# Altium schematic sheet Drive.SCHDOC(Drive)
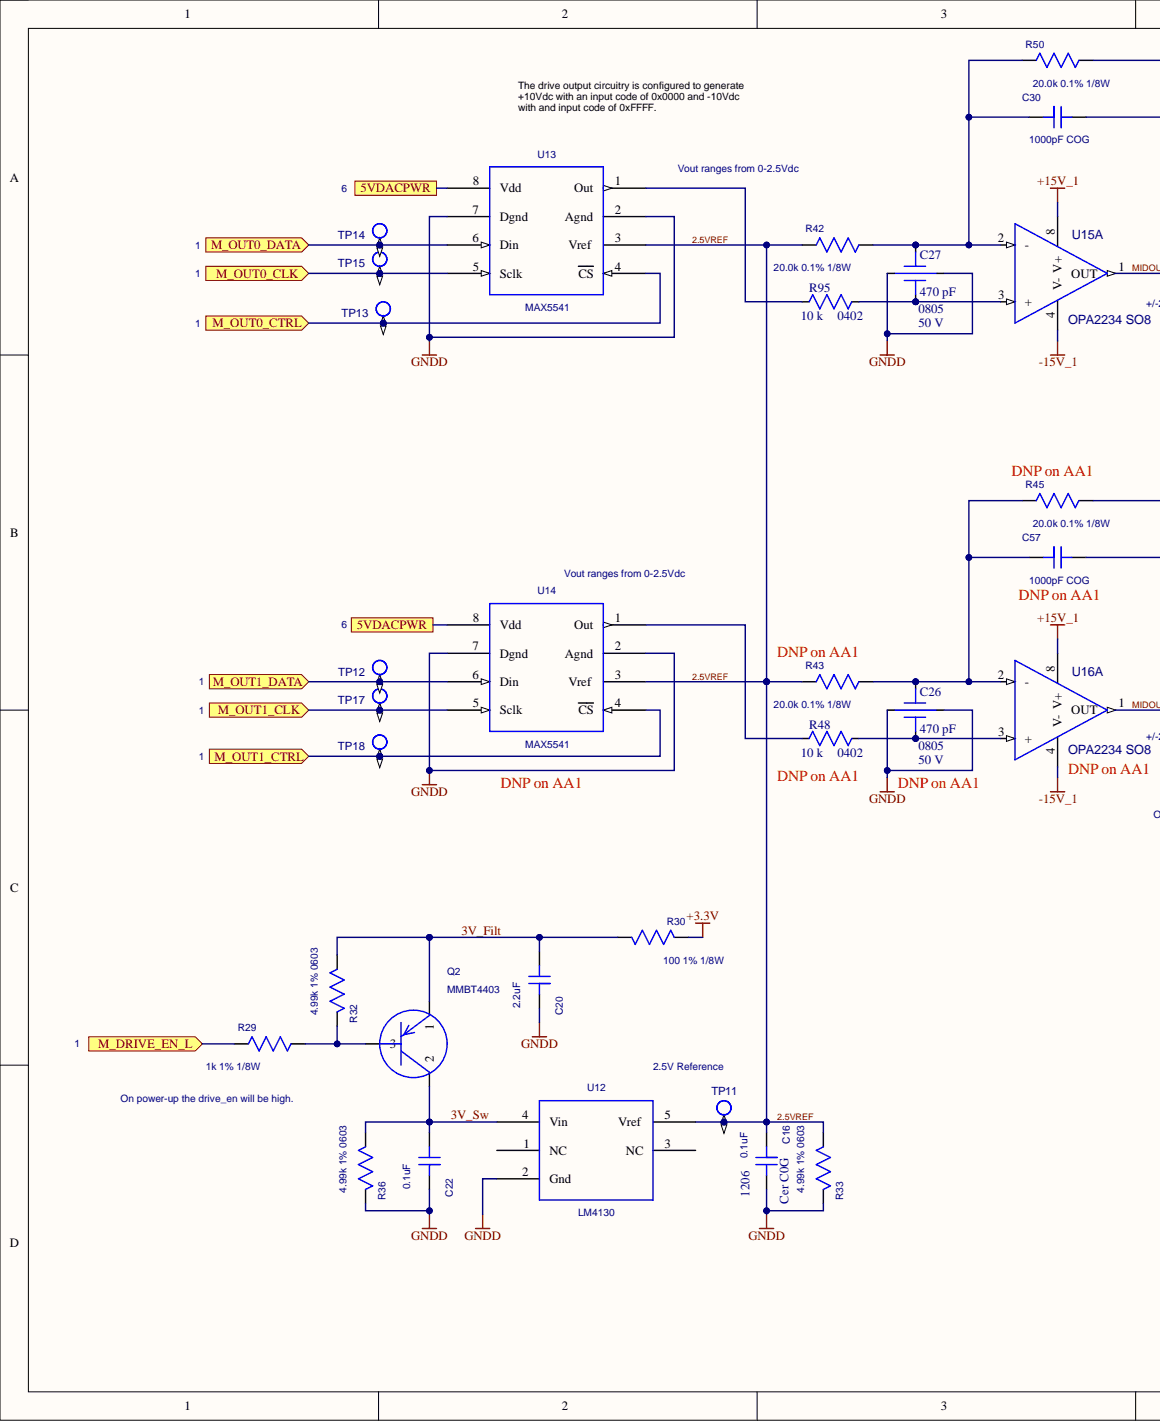
[diagram p0: Drive.SCHDOC, left]
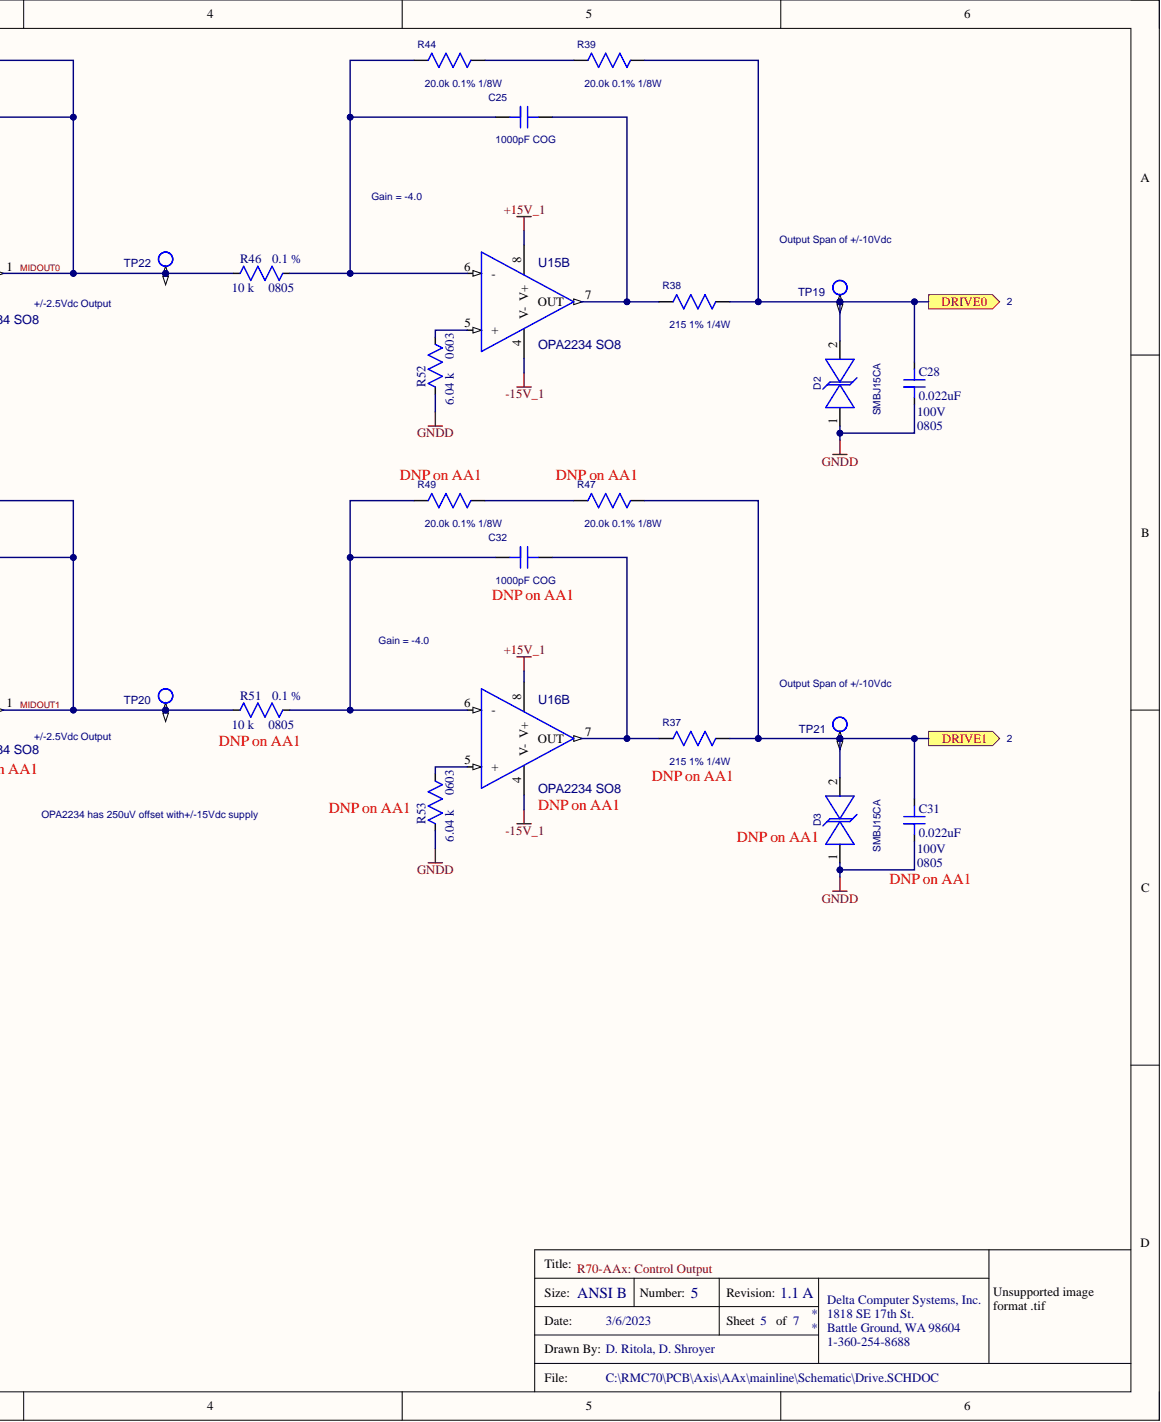
[diagram p1: Drive.SCHDOC, right]

## Parts
C16  0.1uF  Case: 1206  Type: Cer C0G  [CC1206]
C20  2.2uF  [CC0603]
C22  0.1uF  [CC0603]
C25  1000pF COG  [CC0805]
C26  470 pF  CaseSize: 0805  RatedVoltage: 50 V  [CAP X2Y [0805]]
C27  470 pF  CaseSize: 0805  RatedVoltage: 50 V  [CAP X2Y [0805]]
C28  0.022uF  CaseSize: 0805  RatedVoltage: 100V  [CAPC2012-N [0805]]
C30  1000pF COG  [CC0805]
C31  0.022uF  CaseSize: 0805  RatedVoltage: 100V  [CAPC2012-N [0805]]
C32  1000pF COG  [CC0805]
C57  1000pF COG  [CC0805]
D2  SMBJ15CA  [TRANZORBSMT]
D3  SMBJ15CA  [TRANZORBSMT]
Q2  MMBT4403  [SOT346]
R29  1k 1% 1/8W  [CC0805]
R30  100 1% 1/8W  [CC0805]
R32  4.99k 1% 0603  [CC0603]
R33  4.99k 1% 0603  [CC0603]
R36  4.99k 1% 0603  [CC0603]
R37  215 1% 1/4W  [1206]
R38  215 1% 1/4W  [1206]
R39  20.0k 0.1% 1/8W  [CC0805]
R42  20.0k 0.1% 1/8W  [CC0805]
R43  20.0k 0.1% 1/8W  [CC0805]
R44  20.0k 0.1% 1/8W  [CC0805]
R45  20.0k 0.1% 1/8W  [CC0805]
R46  10 k  CaseSize: 0805  Tolerance: 0.1 %  [RESC2012-N [0805]]
R47  20.0k 0.1% 1/8W  [CC0805]
R48  10 k  CaseSize: 0402  [RESC1005-N [0402]]
R49  20.0k 0.1% 1/8W  [CC0805]
R50  20.0k 0.1% 1/8W  [CC0805]
R51  10 k  CaseSize: 0805  Tolerance: 0.1 %  [RESC2012-N [0805]]
R52  6.04 k  CaseSize: 0603  [RESC1608-N [0603]]
R53  6.04 k  CaseSize: 0603  [RESC1608-N [0603]]
R95  10 k  CaseSize: 0402  [RESC1005-N [0402]]
TP11  TP1  [TESTP_XY60D40]  (no BOM)
TP12  TP1  [TESTP_XY60D40]  (no BOM)
TP13  TP1  [TESTP_XY60D40]  (no BOM)
TP14  TP1  [TESTP_XY60D40]  (no BOM)
TP15  TP1  [TESTP_XY60D40]  (no BOM)
TP17  TP1  [TESTP_XY60D40]  (no BOM)
TP18  TP1  [TESTP_XY60D40]  (no BOM)
TP19  TP1  [TESTP_XY60D40]  (no BOM)
TP20  TP1  [TESTP_XY60D40]  (value not drawn)  (no BOM)
TP21  TP1  [TESTP_XY60D40]  (value not drawn)  (no BOM)
TP22  TP1  [TESTP_XY60D40]  (value not drawn)  (no BOM)
U12  LM4130  [SOT23-5]
U13  MAX5541  [SO8]
U14  MAX5541  [SO8]
U15  OPA2234 SO8  [SO8]  (parts A,B)
U16  OPA2234 SO8  [SO8]  (parts A,B)

## Ports
5VDACPWR, DRIVE0, DRIVE1, M_DRIVE_EN_L, M_OUT0_CLK, M_OUT0_CTRL, M_OUT0_DATA, M_OUT1_CLK, M_OUT1_CTRL, M_OUT1_DATA

## Nets
+3.3V: R30.1
+15V_1: U15.8(V+) U16.8(V+)
-15V_1: U15.4(V-) U16.4(V-)
GNDD: C16.1 C20.2 C22.2 C26.3 C26.4 C27.3 C27.4 C28.2 C31.2 D2.1 D3.1 R33.1 R36.1 R52.1 R53.1 U12.2(Gnd) U13.2(Agnd) U13.7(Dgnd) U14.2(Agnd) U14.7(Dgnd)
2.5VREF: C16.2 R33.2 R42.1 R43.1 TP11.1 U12.5(Vref) U13.3(Vref) U14.3(Vref)
3V_Filt: C20.1 Q2.1 R30.2 R32.2
3V_Sw: C22.1 Q2.2 R36.2 U12.4(Vin)
5VDACPWR: U13.8(Vdd) U14.8(Vdd) ; port 5VDACPWR
DRIVE0: C28.1 D2.2 R38.2 R39.2 TP19.1 ; port DRIVE0
DRIVE1: C31.1 D3.2 R37.2 R47.2 TP21.1 ; port DRIVE1
M_DRIVE_EN_L: R29.1 ; port M_DRIVE_EN_L
M_OUT0_CLK: TP15.1 U13.5(Sclk) ; port M_OUT0_CLK
M_OUT0_CTRL: TP13.1 U13.4(CS) ; port M_OUT0_CTRL
M_OUT0_DATA: TP14.1 U13.6(Din) ; port M_OUT0_DATA
M_OUT1_CLK: TP17.1 U14.5(Sclk) ; port M_OUT1_CLK
M_OUT1_CTRL: TP18.1 U14.4(CS) ; port M_OUT1_CTRL
M_OUT1_DATA: TP12.1 U14.6(Din) ; port M_OUT1_DATA
MIDOUT0: C30.1 R46.1 R50.2 TP22.1 U15.1(OUT)
MIDOUT1: C57.1 R45.2 R51.1 TP20.1 U16.1(OUT)
NetC25_1: C25.1 R38.1 U15.7(OUT)
NetC25_2: C25.2 R44.1 R46.2 U15.6
NetC26_1: C26.1 C57.2 R43.2 R45.1 U16.2(-)
NetC26_2: C26.2 R48.2 U16.3(+)
NetC27_1: C27.1 C30.2 R42.2 R50.1 U15.2(-)
NetC27_2: C27.2 R95.2 U15.3(+)
NetC32_1: C32.1 R37.1 U16.7(OUT)
NetC32_2: C32.2 R49.1 R51.2 U16.6
NetQ2_3: Q2.3 R29.2 R32.1
NetR39_1: R39.1 R44.2
NetR47_1: R47.1 R49.2
NetR48_1: R48.1 U14.1(Out)
NetR52_2: R52.2 U15.5
NetR53_2: R53.2 U16.5
NetR95_1: R95.1 U13.1(Out)

Not connected: U12 1(NC) 3(NC)

## Library data of the parts
C16 = CAPNP_SMT1206: Cap Cer 0.1uF 25V 5% 1206 C0G; Manufacturer: Venkel; PartNumber: C1206C0G250-104JNE; Notes: ECA0102; Component Kind: Standard; Value: 0.1uF
C20 = CAPNP_SMT0603: Cap Cer 2.2uF 10V 10% 0603 X7R; Manufacturer: Venkel; PartNumber: C0603X7R100-225KNE; Notes: ECA0085; Case: 0603; Component Kind: Standard; Value: 2.2uF; Voltage: 10V
C22 = CAPNP_SMT0603: Cap Cer 0.1uF 50V 10% 0603 X7R; Manufacturer: Venkel; PartNumber: C0603X7R500-104KNE; Component Kind: Standard; Value: 0.1uF
C25 C30 C32 C57 = CAPNP_SMT0805: Cap Cer 1000pF 50V 5% 0805 C0G; Manufacturer: Kemet; PartNumber: C0805C102J5GACTU; Component Kind: Standard; Value: 1000pF COG
C26 C27 = Capacitor - X2Y: Cap Cer 470pF 50V 20% 0805 C0G X2Y; Manufacturer: Johanson Dielectric; ManufacturerAlt1: Yageo; PartNumber: 500X15N471MV4E; Type: Ceramic X2Y C0G; Component Kind: Standard; ComponentType: Capacitor; DateUpdated: 02/06/2023; Library Name: Capacitor.IntLib; Tolerance: 20%; Value: 470 pF
C28 C31 = Capacitor - Non-polarized: Cap Cer 0.022uF 100V 10% 0805 X7R; Manufacturer: Venkel; ManufacturerAlt1: Various; PartNumber: C0805X7R101-223KNE; Notes: ECA0087; Type: Ceramic X7R; Component Kind: Standard; ComponentType: Capacitor; DateUpdated: 02/06/2023; Library Name: Capacitor.IntLib; PartNumberAlt1: Various; Tolerance: 10%; Value: 0.022uF
D2 D3 = SMBJ15CA: TVS Diode 15V Bidirectional SMB; Manufacturer: Fairchild; Component Kind: Standard; PartNumber: SMBJ15CA
Q2 = MMBT4403: Transistor PNP 0.6A 40V SOT23; Manufacturer: ON Semi; PartNumber: MMBT4403LT1G; Component Kind: Standard
R29 = RES_GEN_SMT0805: Res 1k 1% 1/8W 0805; Manufacturer: Venkel; PartNumber: CR0805-8W-1001FT; Component Kind: Standard; Value: 1k 1% 1/8W
R30 = RES_GEN_SMT0805: Res 100 1% 1/8W 0805; Manufacturer: Venkel; PartNumber: CR0805-8W-1000FT; Component Kind: Standard; Value: 100 1% 1/8W
R32 R33 R36 = RES_GEN_SMT0603: Res 4.99k 1% 1/10W 0603; Manufacturer: Venkel; PartNumber: CR0603-10W-4991FT; Notes: ERA0020; Component Kind: Standard; Value: 4.99k 1% 0603
R37 R38 = RES_GEN_1206_VARIANT_1: Res 215 1% 1/4W 1206; Manufacturer: Venkel; PartNumber: CR1206-4W-2150FT; Component Kind: Standard; Value: 215 1% 1/4W
R39 R42-R45 R47 R49 R50 = RES_GEN_SMT0805: Res 20k 0.1% 1/8W 0805; Manufacturer: Panasonic; PartNumber: ERA-6AEB203V; Component Kind: Standard; Value: 20.0k 0.1% 1/8W
R46 R51 = Resistor: Res 10k 0.1% 1/8W 0805; Manufacturer: Venkel; ManufacturerAlt1: Various; PartNumber: TFCR0805-8W-E-1002BT; Notes: ERA0030; Component Kind: Standard; ComponentType: Resistor; DateUpdated: 01/31/2023; Library Name: Resistor.IntLib; PartNumberAlt1: Various; PowerRating: 1/8 W; Value: 10 k
R48 R95 = Resistor: Res 10k 1% 1/16W 0402; Manufacturer: Venkel; ManufacturerAlt1: Various; PartNumber: CR0402-16W-1002FT; Notes: ERA0095; Tolerance: 1.0%; Component Kind: Standard; ComponentType: Resistor; DateUpdated: 01/31/2023; Library Name: Resistor.IntLib; PartNumberAlt1: Various; PowerRating: 1/16 W; Value: 10 k
R52 R53 = Resistor: Res 6.04k 1% 1/10W 0603; Manufacturer: Venkel; ManufacturerAlt1: Various; PartNumber: CR0603-10W-6041FT; Notes: ERA0132; Tolerance: 1.0%; Component Kind: Standard; ComponentType: Resistor; DateUpdated: 01/31/2023; Library Name: Resistor.IntLib; PartNumberAlt1: Various; PowerRating: 1/10 W; Value: 6.04 k
TP11-TP15 TP17-TP22 = TP1: PDIF_I_PID: TP0; PDIF_TY: 255; Description: TP1
U12 = LM4130: Volt Ref Series 20mA 2.5V SOT235; Manufacturer: TI; PartNumber: LM4132BMF-2.5/NOPB; Component Kind: Standard
U13 U14 = MAX5541: DAC 16bit Monotonic Serial 8SOIC; Manufacturer: Maxim; PartNumber: MAX5541CSA+; Component Kind: Standard
U15 U16 = OPA2234 SO8: OpAmp GP 350kHz 8SOIC; Manufacturer: TI; PartNumber: OPA2234UA/2K5; Component Kind: Standard
